# Altium schematic sheet 3_Radio.SchDoc
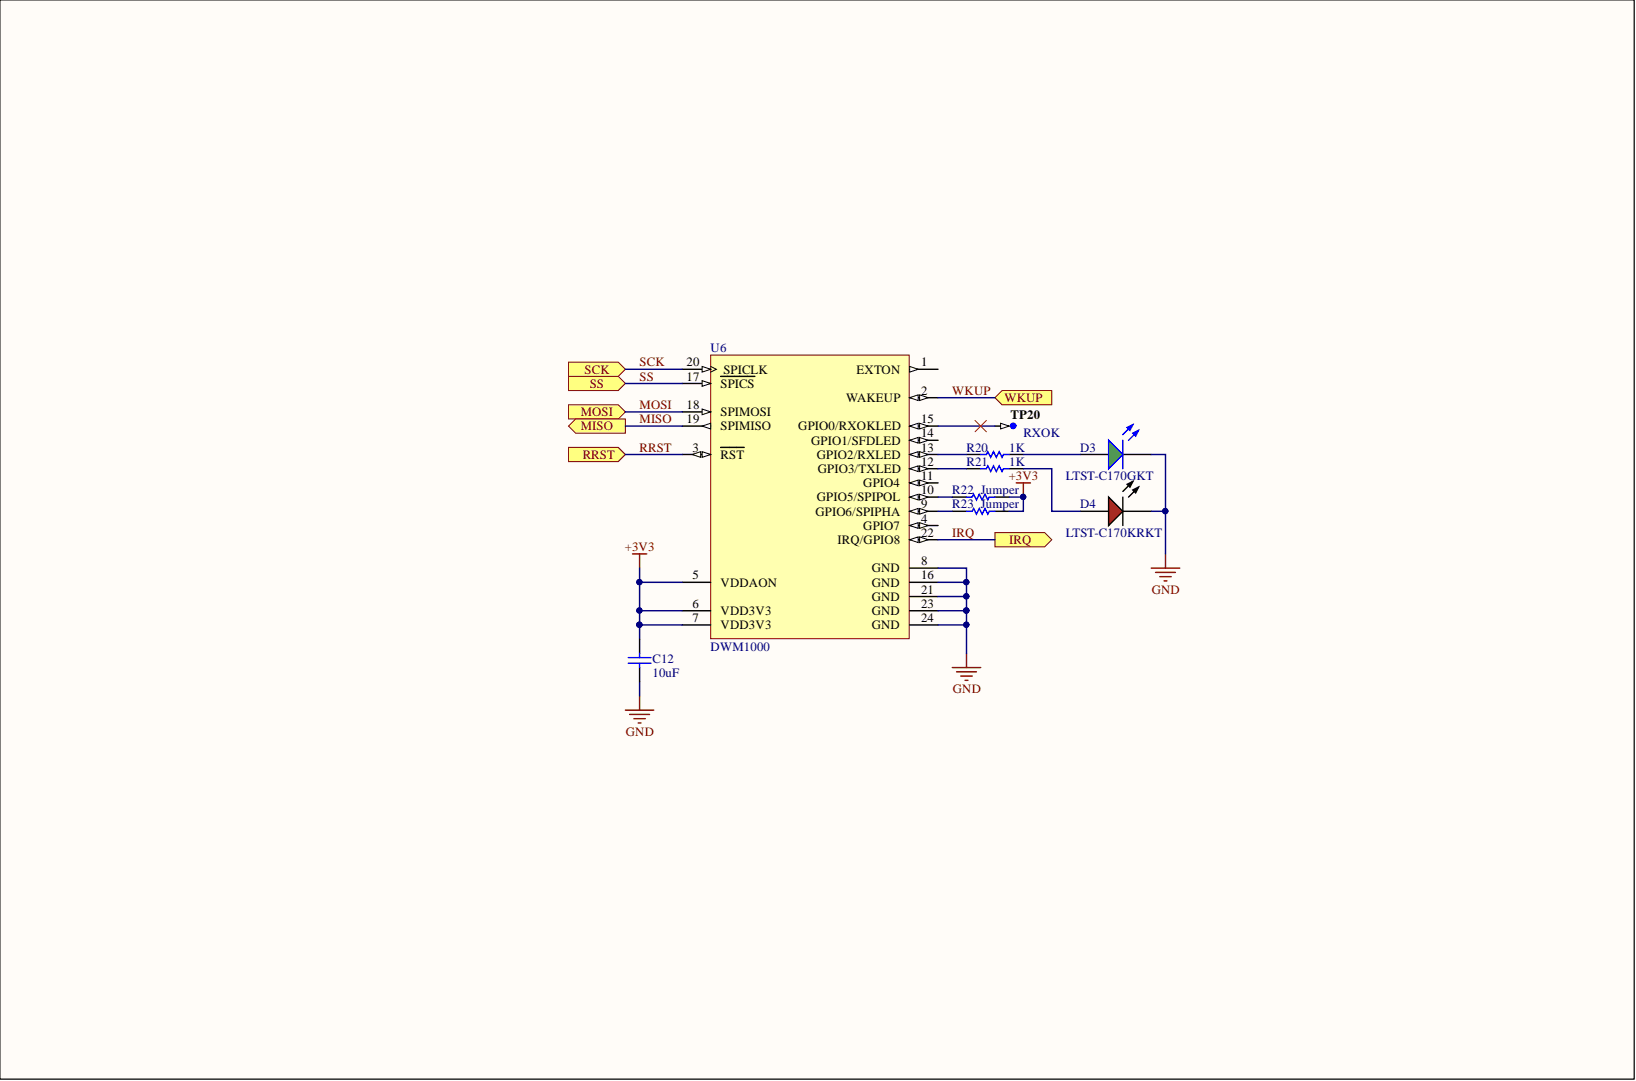
[diagram p0: 3_Radio.SchDoc]

## Parts
C12  10uF  [CAPC1206(3216)130_L]
D3  LTST-C170GKT  [LTST-C170GKT_V]
D4  LTST-C170KRKT  [LTST-C170KRKT_V]
R20  1K  [RESC0603(1608)_M]
R21  1K  [RESC0603(1608)_M]
R22  Jumper  [RESC0805(2012)_M]
R23  Jumper  [RESC0805(2012)_M]
TP20  RXOK  [TP-SM-C-100]  (no BOM)
U6  DWM1000  [DECW-DWM1000-24_V]

## Ports
IRQ, MISO, MOSI, RRST, SCK, SS, WKUP

## Nets
+3V3: C12.2 R22.1 R23.1 U6.5(VDDAON) U6.6(VDD3V3) U6.7(VDD3V3)
GND: C12.1 D3.C D4.2 U6.8(GND) U6.16(GND) U6.21(GND) U6.23(GND) U6.24(GND)
IRQ: U6.22(IRQ/GPIO8) ; port IRQ
MISO: U6.19(SPIMISO) ; port MISO
MOSI: U6.18(SPIMOSI) ; port MOSI
RRST: U6.3(RST) ; port RRST
SCK: U6.20(SPICLK) ; port SCK
SS: U6.17(SPICS) ; port SS
WKUP: U6.2(WAKEUP) ; port WKUP
NetD3_A: D3.A R20.1
NetD4_1: D4.1 R21.1
NetR20_2: R20.2 U6.13(GPIO2/RXLED)
NetR21_2: R21.2 U6.12(GPIO3/TXLED)
NetR22_2: R22.2 U6.10(GPIO5/SPIPOL)
NetR23_2: R23.2 U6.9(GPIO6/SPIPHA)
NetTP20_1: TP20.1 U6.15(GPIO0/RXOKLED)

Not connected: U6 1(EXTON) 4(GPIO7) 11(GPIO4) 14(GPIO1/SFDLED)

## Library data of the parts
C12 = CMP-1037-04977-1: CAP 10uF 16V -20% to +80% 1206 (3216 Metric) Thickness 1.3mm SMD; Case-EIA: 1206; Case-Metric: 3216; Component Kind: Standard; Library Name: Altium Content Vault; Max Thickness: 1.3 mm; Rated Voltage: 16 V; Technology: SMT; Tolerance: -20% to +80%; Value: 10uF
D3 = CMP-1674-00002-3: LED GREEN CLEAR 0805 SMD; Manufacturer: Lite-On; Component Kind: Standard; ComponentLink1Description: Datasheet; ComponentLink1URL: http://media.digikey.com/pdf/Data%20Sheets/Lite-On%20PDFs/LTST-C170GKT.pdf; Library Name: Altium Content Vault; PackageReference: C170GKT; PartNumber: LTST-C170GKT
D4 = CMP-2000-07593-1: LED Red, 75 mW, 54 mcd, -55 to 85 degC, 2-Pin SMD, RoHS, Tape and Reel; Manufacturer: Lite-On; Case\/Package: 0805; Dominant Wavelength: 631nm; Forward Current: 30mA; Forward Voltage: 2V; Lens Colour: Colorless; Lens Style: Rectangular; Lens Transparency: Clear; Luminous Intensity: 54mcd; Number of Elements: 1; Power Dissipation: 75mW; Test Current: 20mA; Viewing Angle: 130degrees; Wavelength: 639nm; Case Code (Imperial): 0805; Case Code (Metric): 2012; Colour: Red; Component Kind: Standard; Datasheet URL: http://optoelectronics.liteon.com/upload/download/DS-22-99-0150/LTST-C170KRKT.pdf; Datasheet Version: Rev. D, 11/2014; Depth: 1.6mm; Height: 1.1mm; Illumination Colour: Red; Lead Free: LeadFree; Length: 3.2mm; Library Name: Altium Content Vault; Manufacturer URL: http://www.us.liteon.com/; Max Operating Temperature: 85degC; Min Operating Temperature: -55degC; Mount: SurfaceMount; Mounting Technology: SurfaceMount; Number of LEDs: 1; Number of Pins: 2; Package Description: 2-Pin Surface Mount Device, Body 2 x 1.25 mm; Package Quantity: 1; Package Reference: C170KRKT; Packaging: TapeandReel; Power Rating: 75mW; Radiation Hardening: No; RoHSCompliant: True; Width: 1.25mm
R20 R21 = CMP-1012-00510-1: 1K 0.1W 1% 0603 (1608 Metric)  SMD; Case-EIA: 0603; Case-Metric: 1608; Value: 1000.00 Ohm; Component Kind: Standard; Library Name: Altium Content Vault; Power: 0.1 W; Technology: SMT; Tolerance: 1 %
R22 R23 = CMP-1013-00001-1: Jumper 0805 (2012 Metric); Case-EIA: 0805; Case-Metric: 2012; Power: None; Value: 0.00 Ohm; Component Kind: Standard; Library Name: Altium Content Vault; Technology: SMT; Tolerance: None
TP20 = CMP-0484-00002-2: Test Point, Surface Mount, Circular, Pad Diameter 1 mm; Manufacturer: No Vendor; Library Name: Altium Content Vault; PartNumber: TP-SM-C-100
U6 = CMP-2000-05094-1: DW M1000 IEEE 802.15.4 -2011 UWB Transceiver Module; Manufacturer: Decawave; Antenna Type: Integrated,Chip; Current - Receiving: 64mA; Current - Transmitting: 31mA; Data Rate: 6.8Mbps; Design Resources: DevelopmentToolSelector; Featured Product: ScenSorDWM1000Module; Frequency: 3.5GHz~6.5GHz; Modulation: BPM,BPSK; Other Names: 1479-1002-2RUPF-G001A; Protocol: IR-UWB; Serial Interfaces: SPI; Voltage - Supply: 2.8V~3.6V; Category: RF/IFandRFID; Component Kind: Standard; Datasheet URL: http://www.decawave.com/sites/default/files/resources/dwm1000-datasheet-v1.3.pdf; Datasheet Version: Rev. V1.0, 12/2015; Family: RFTransceiverModules; Library Name: Altium Content Vault; Manufacturer URL: http://www.decawave.com/; Memory Size: -; Mounting Type: SurfaceMount; Online Catalog: ScenSorDWM1000Module; Operating Temperature: -40degC~85degC; Package / Case: Module; Packaging: Tape&Reel(TR); Power - Output: -; RF Family/Standard: 802.15.4; Sensitivity: -; Series: -; Standard Package: 500
